# Altium schematic sheet Power_meter.SchDoc
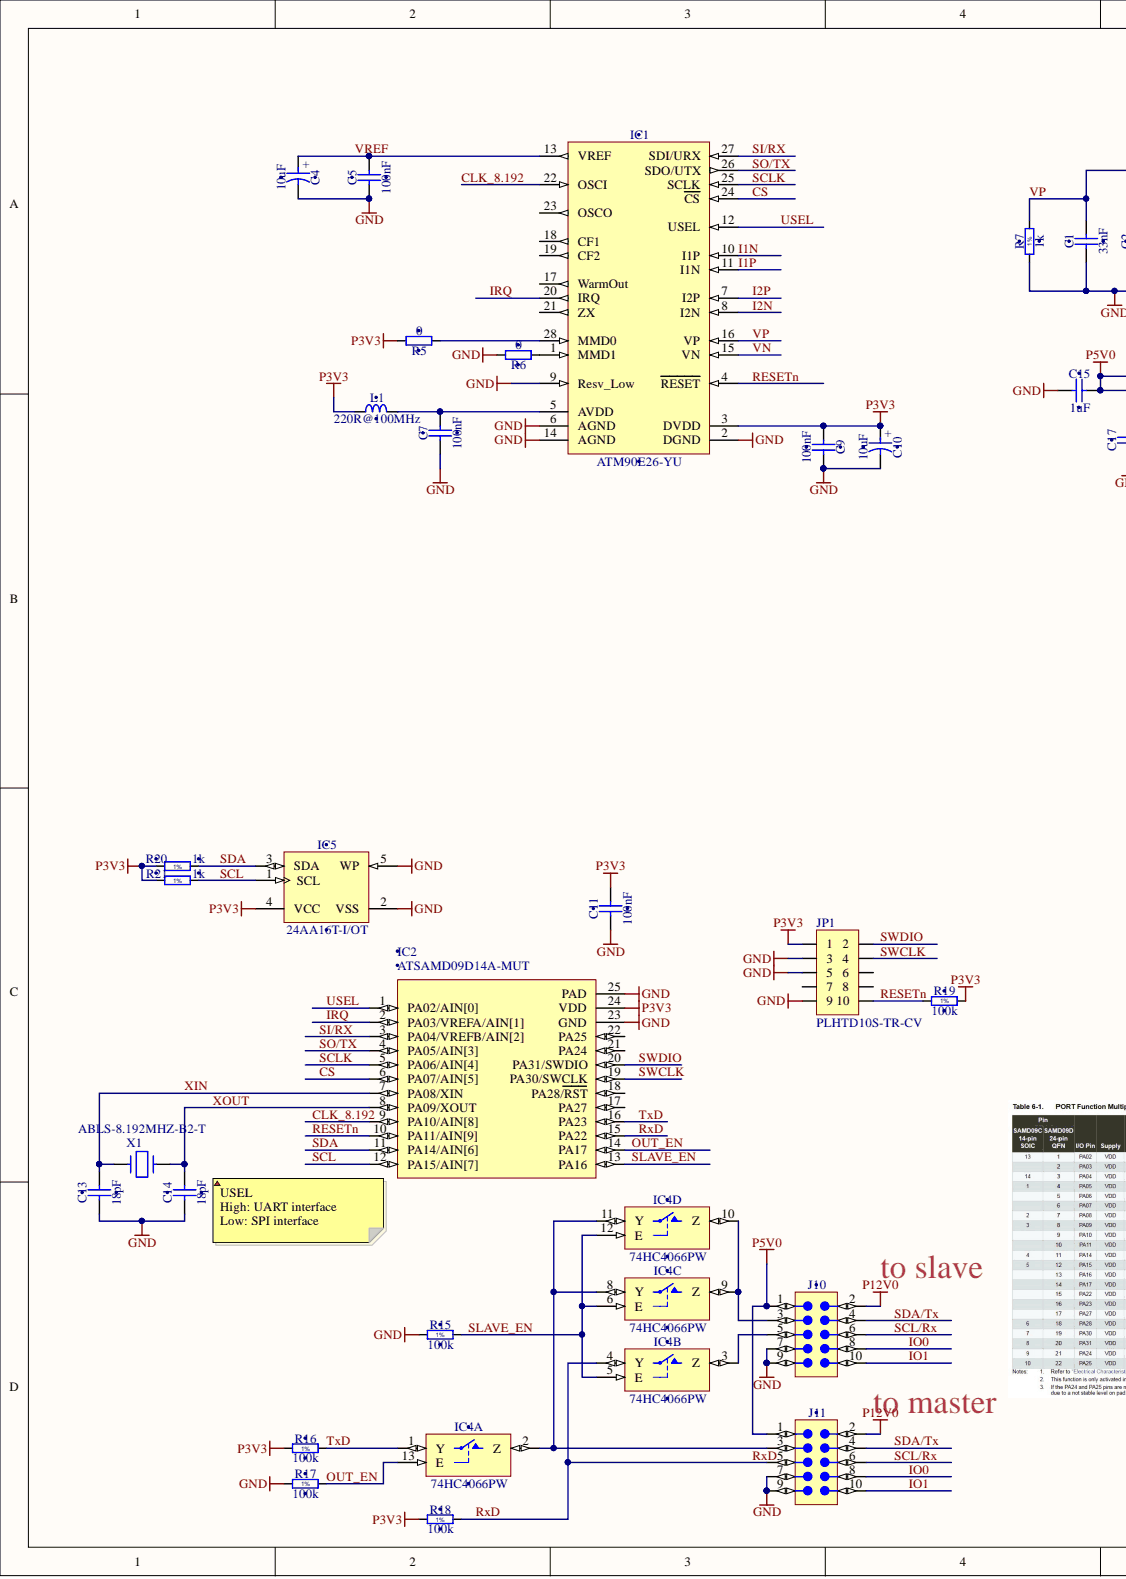
[diagram p0: Power_meter.SchDoc, left]
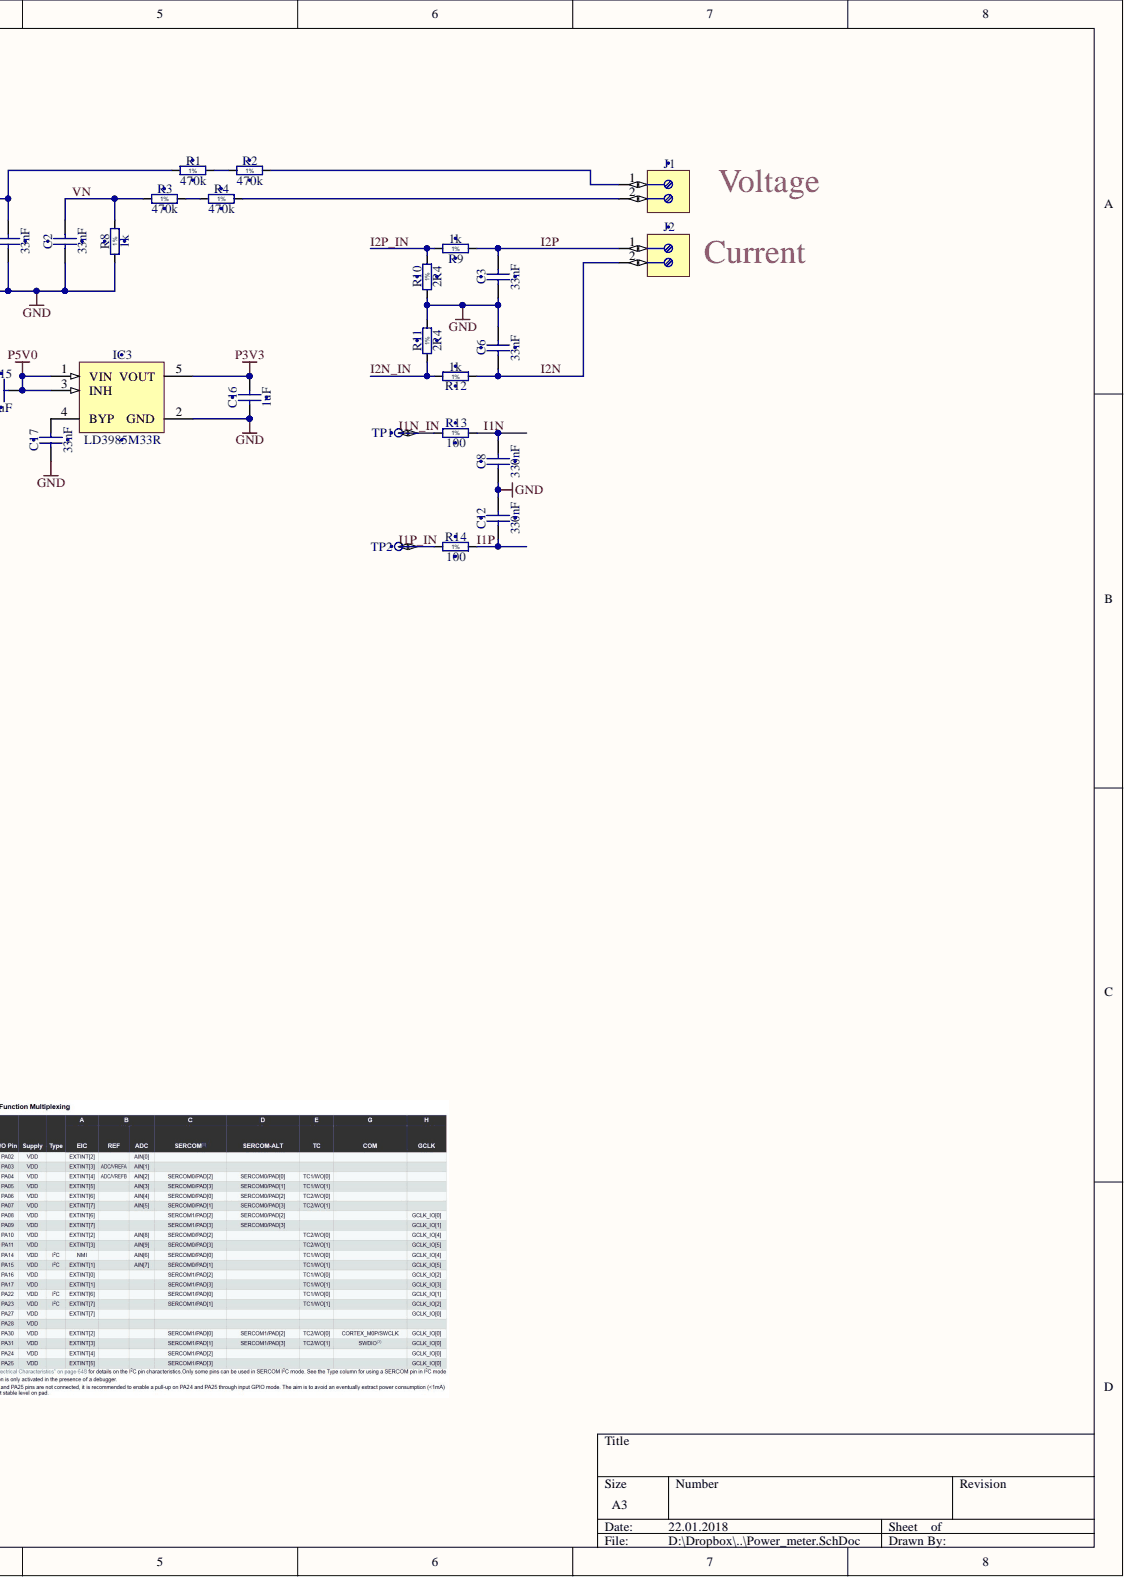
[diagram p1: Power_meter.SchDoc, right]

## Parts
C1  33nF  [CAPC1005X55N]
C2  33nF  [CAPC1005X55N]
C3  33nF  [CAPC1005X55N]
C4  10uF  [CAPMP3216X180N]
C5  100nF  [CAPC1005X55N]
C6  33nF  [CAPC1005X55N]
C7  100nF  [CAPC1005X55N]
C8  330nF  [CAPC1608X87N]
C9  100nF  [CAPC1005X55N]
C10  10uF  [CAPMP3216X180N]
C11  100nF  [CAPC1005X55N]
C12  330nF  [CAPC1608X87N]
C13  18pF  [CAPC1005X55N]
C14  18pF  [CAPC1005X55N]
C15  1uF  [CAPC1005X55N]
C16  1uF  [CAPC1005X55N]
C17  33nF  [CAPC1005X55N]
IC1  ATM90E26-YU  [SOP65P780X200-28N]
IC2  ATSAMD09D14A-MUT  [QFN50P400X400X80_HS-25N]
IC3  LD3985M33R  [SOT95P280X145-5N]
IC4  74HC4066PW  [SOP65P640X110-14N]  (parts A,B,C,D)
IC5  24AA16T-I/OT  [SOT95P280X145-5N]
J1  Family  [PHOENIX_1751248]  (value not drawn)
J2  Family  [PHOENIX_1725656]  (value not drawn)
J10  edge  [AVX_00-9159-010-061-916]  (value not drawn)
J11  edge  [AVX_00-9159-010-061-916]  (value not drawn)
JP1  PLHTD10S-TR-CV  [PLHTD10S-TR-CV]
L1  220R@100MHz  [INDC1005X55N]
R1  470k  [RESC3216X65N]
R2  470k  [RESC3216X65N]
R3  470k  [RESC3216X65N]
R4  470k  [RESC3216X65N]
R5  0  [RESC1005X40N]
R6  0  [RESC1005X40N]
R7  1k  [RESC1005X40N]
R8  1k  [RESC1005X40N]
R9  1k  [RESC1005X40N]
R10  2R4  [RESC1608X55N]
R11  2R4  [RESC1608X55N]
R12  1k  [RESC1005X40N]
R13  100  [RESC1005X40N]
R14  100  [RESC1005X40N]
R15  100k  [RESC1005X40N]
R16  100k  [RESC1005X40N]
R17  100k  [RESC1005X40N]
R18  100k  [RESC1005X40N]
R19  100k  [RESC1005X40N]
R20  1k  [RESC1005X40N]
R21  1k  [RESC1005X40N]
TP1  Test Point  [TPS127]  (value not drawn)  (no BOM)
TP2  Test Point  [TPS127]  (value not drawn)  (no BOM)
X1  ABLS-8.192MHZ-B2-T  [HC-49SM]

## Nets
GND: C1.1 C2.1 C3.1 C4.2 C5.1 C6.2 C7.1 C8.1 C9.2 C10.2 C11.1 C12.2 C13.1 C14.1 C15.1 C16.1 C17.1 IC1.2(DGND) IC1.6(AGND) IC1.9(Resv_Low) IC1.14(AGND) IC2.23(GND) IC2.25(PAD) IC3.2(GND) IC4.7 IC5.2(VSS) IC5.5(WP) J10.7 J10.9 J11.7 J11.9 JP1.3 JP1.5 JP1.9 R6.2 R7.1 R8.1 R10.1 R11.2 R15.1 R17.1
P3V3: C9.1 C10.1 C11.2 C16.2 IC1.3(DVDD) IC2.24(VDD) IC3.5(VOUT) IC4.14 IC5.4(VCC) JP1.1 L1.1 R5.2 R16.1 R18.1 R19.2 R20.2 R21.2
P5V0: C15.2 IC3.1(VIN) IC3.3(INH) J10.1 J11.1
P12V0: J10.2 J11.2
CLK_8.192: IC1.22(OSCI) IC2.9(PA10/AIN[8])
CS: IC1.24(CS) IC2.6(PA07/AIN[5])
I1N: C8.2 IC1.10(I1P) R13.2
I1N_IN: R13.1 TP1.1
I1P: C12.1 IC1.11(I1N) R14.2
I1P_IN: R14.1 TP2.1
I2N: C6.1 IC1.8(I2N) J2.2 R12.1
I2N_IN: R11.1 R12.2
I2P: C3.2 IC1.7(I2P) J2.1 R9.1
I2P_IN: R9.2 R10.2
IO0: J10.8 J11.8
IO1: J10.10 J11.10
IRQ: IC1.20(IRQ) IC2.2(PA03/VREFA/AIN[1])
OUT_EN: IC2.14(PA17) IC4.13(E) R17.2
RESETn: IC1.4(RESET) IC2.10(PA11/AIN[9]) JP1.10 R19.1
RxD: IC2.15(PA22) IC4.4(Y) J11.5 R18.2
SCL: IC2.12(PA15/AIN[7]) IC5.1(SCL) R21.1
SCL/Rx: J10.6 J11.6
SCLK: IC1.25(SCLK) IC2.5(PA06/AIN[4])
SDA: IC2.11(PA14/AIN[6]) IC5.3(SDA) R20.1
SDA/Tx: J10.4 J11.4
SI/RX: IC1.27(SDI/URX) IC2.3(PA04/VREFB/AIN[2])
SLAVE_EN: IC2.13(PA16) IC4.5(E) IC4.6(E) IC4.12(E) R15.2
SO/TX: IC1.26(SDO/UTX) IC2.4(PA05/AIN[3])
SWCLK: IC2.19(PA30/SWCLK) JP1.4
SWDIO: IC2.20(PA31/SWDIO) JP1.2
TxD: IC2.16(PA23) IC4.1(Y) R16.2
USEL: IC1.12(USEL) IC2.1(PA02/AIN[0])
VN: C2.2 IC1.15(VN) R3.1 R8.2
VP: C1.2 IC1.16(VP) R1.1 R7.2
VREF: C4.1 C5.2 IC1.13(VREF)
XIN: C13.2 IC2.7(PA08/XIN) X1.1
XOUT: C14.2 IC2.8(PA09/XOUT) X1.2
NetC7_2: C7.2 IC1.5(AVDD) L1.2
NetC17_2: C17.2 IC3.4(BYP)
NetIC1_1: IC1.1(MMD1) R6.1
NetIC1_28: IC1.28(MMD0) R5.1
NetIC4_2: IC4.2(Z) IC4.8(Y) IC4.11(Y) J11.3
NetIC4_3: IC4.3(Z) J10.5
NetIC4_9: IC4.9(Z) IC4.10(Z) J10.3
NetJ1_1: J1.1 R2.2
NetJ1_2: J1.2 R4.2
NetR1_2: R1.2 R2.1
NetR3_2: R3.2 R4.1

Not connected: IC1 17(WarmOut) 18(CF1) 19(CF2) 21(ZX) 23(OSCO); IC2 17(PA27) 18(PA28/RST) 21(PA24) 22(PA25); JP1 6 7 8

## Library data of the parts
C1-C3 C6 C17 = CC0402_33NF_16V_10%_X7R: Non-polarised capacitor; Manufacturer: GENERIC; Manufacturer1 Part Number: 223878715643; Part Description: SMD Multilayer Chip Ceramic Capacitor; Database Table Name: Capacitors SMD; TC: X7R; Tolerance: ±10%; Voltage: 16V; Case: 0402; Component Kind: Standard; Component Type: Standard; CreateDate: 03.12.2007; HelpURL: \\cern.ch\dfs\Applications\Altium\Datasheets\CC0402_X7R_PHYCOMP.pdf; LatestRevisionDate: 03.12.2007; Library Name: Capacitors.DbLib; Manufacturer1 ComponentHeight: 0.55mm; Manufacturer1 Example: PHYCOMP; Manufacturer Part Number: CC0402_33NF_16V_10%_X7R; Mounted: Yes; Part Number: CC0402_33NF_16V_10%_X7R; Pin Count: 2; Sense: No; SMD: Yes; Socket: No; Status: Not Recommended; Value: 33nF; Author: CERN DEM JLC
C4 C10 = CTE3216-18_10UF_16V_20%_AVX_TCJ: Polarised capacitor; Manufacturer: AVX; Manufacturer Part Number: TCJA106M016R0200; Part Description: ESR 0R200 Tantalum Solid Electrolytic Chip Capacitor with Conductive Polymer Electrode; Database Table Name: Capacitors SMD; Tolerance: ±20%; Voltage: 16V; Case: A; ComponentHeight: 1.8mm; Component Kind: Standard; Component Type: Standard; CreateDate: 29.03.2012; HelpURL: \\cern.ch\dfs\Applications\Altium\Datasheets\CTE_AVX_TCJ.pdf; LatestRevisionDate: 29.03.2012; Library Name: Capacitors.DbLib; Mounted: Yes; Part Number: CTE3216-18_10UF_16V_20%_AVX_TCJ; Pin Count: 2; Sense: No; SMD: Yes; Socket: No; Status: Preferred; Value: 10uF; Author: CERN DEM JLC
C5 C7 C9 C11 = CC0402_100NF_16V_10%_X5R: Non-polarised capacitor; Manufacturer: GENERIC; Manufacturer1 Part Number: GRM155R61C104KA88D; Part Description: SMD Multilayer Chip Ceramic Capacitor; Database Table Name: Capacitors SMD; TC: X5R; Tolerance: ±10%; Voltage: 16V; Case: 0402; Component Kind: Standard; Component Type: Standard; CreateDate: 10.12.2013; HelpURL: \\cern.ch\dfs\Applications\Altium\Datasheets\CC0402_X5R_MURATA_GRM.pdf; LatestRevisionDate: 10.12.2013; Library Name: Capacitors.DbLib; Manufacturer1 ComponentHeight: 0.55mm; Manufacturer1 Example: MURATA; Manufacturer Part Number: CC0402_100NF_16V_10%_X5R; Mounted: Yes; Part Number: CC0402_100NF_16V_10%_X5R; Pin Count: 2; Sense: No; SMD: Yes; Socket: No; Status: None; Value: 100nF; Author: CERN DEM JLC
C8 C12 = CC0603_330NF_16V_10%_X5R: Non-polarised capacitor; Manufacturer: GENERIC; Manufacturer1 Part Number: 223878613656; Part Description: SMD Multilayer Chip Ceramic Capacitor; Database Table Name: Capacitors SMD; TC: X5R; Tolerance: ±10%; Voltage: 16V; Case: 0603; Component Kind: Standard; Component Type: Standard; CreateDate: 03.12.2007; HelpURL: \\cern.ch\dfs\Applications\Altium\Datasheets\CC0805_X5R_PHYCOMP_HC.pdf; LatestRevisionDate: 03.12.2007; Library Name: Capacitors.DbLib; Manufacturer1 ComponentHeight: 0.87mm; Manufacturer1 Example: PHYCOMP; Manufacturer Part Number: CC0603_330NF_16V_10%_X5R; Mounted: Yes; Part Number: CC0603_330NF_16V_10%_X5R; Pin Count: 2; Sense: No; SMD: Yes; Socket: No; Status: Preferred; Value: 330nF; Author: CERN DEM JLC
C13 C14 = CC0402_18PF_50V_5%_C0G: Non-polarised capacitor; Manufacturer: GENERIC; Manufacturer1 Part Number: C0402C180J5GACTU; Part Description: SMD Multilayer Chip Ceramic Capacitor; Database Table Name: Capacitors SMD; TC: C0G/NP0; Tolerance: ±5%; Voltage: 50V; Case: 0402; Component Kind: Standard; Component Type: Standard; CreateDate: 04.10.2016; HelpURL: \\cern.ch\dfs\Applications\Altium\Datasheets\CC0805_C0G_KEMET_(Commercial Grade).pdf; LatestRevisionDate: 04.10.2016; Library Name: Capacitors.DbLib; Manufacturer1 ComponentHeight: 0.55mm; Manufacturer1 Example: KEMET; Manufacturer Part Number: CC0402_18PF_50V_5%_C0G; Mounted: Yes; Part Number: CC0402_18PF_50V_5%_C0G; Pin Count: 2; Sense: No; SMD: Yes; Socket: No; Status: Preferred; Value: 18pF; Author: CERN DEM JLC
C15 C16 = CC0402_1UF_16V_10%_X5R: Non-polarised capacitor; Manufacturer: GENERIC; Manufacturer1 Part Number: C1005X5R1C105KT; Part Description: SMD Multilayer Chip Ceramic Capacitor; Database Table Name: Capacitors SMD; TC: X5R; Tolerance: ±10%; Voltage: 16V; Case: 0402; Component Kind: Standard; Component Type: Standard; CreateDate: 25.10.2012; HelpURL: \\cern.ch\dfs\Applications\Altium\Datasheets\CC0402_X5R_TDK_C.pdf; LatestRevisionDate: 25.10.2012; Library Name: Capacitors.DbLib; Manufacturer1 ComponentHeight: 0.55mm; Manufacturer1 Example: TDK; Manufacturer Part Number: CC0402_1UF_16V_10%_X5R; Mounted: Yes; Part Number: CC0402_1UF_16V_10%_X5R; Pin Count: 2; Sense: No; SMD: Yes; Socket: No; Status: Preferred; Value: 1uF; Author: CERN DEM JLC
IC1 = ATM90E26-YU: Single-Phase High-Performance Wide-Span, Energy Metering IC; Manufacturer: MICROCHIP TECHNOLOGY; Bonding: No; Database Table Name: Analog & Interface; PressFit: No; Case: SSOP28; ComponentHeight: 2mm; Component Kind: Standard; Component Type: Standard; CreateDate: 13.12.2017; Device: ATM90E26-YU; HelpURL: \\cern.ch\dfs\Applications\Altium\Datasheets\ATM90E26-YU.pdf; LatestRevisionDate: 13.12.2017; Library Name: ICs And Semiconductors.DbLib; Manufacturer Part Number: ATM90E26-YU; Mounted: Yes; PackageDescription: SOP, 0.65mm Pitch; 28 pin, 5.30mm W X 10.20mm L X 2.00mm H body, IPC Medium Density; Part Description: Single-Phase High-Performance Wide-Span, Energy Metering IC; Part Number: ATM90E26-YU; Pin Count: 28; Sense: No; SMD: Yes; Socket: No; Author: CERN DEM MR
IC2 = (no symbol name): 32-bit ARM®-based Cortex®-M4 core Microcontroller, 1.62V to 3.6V, 120MHz, 256KB Flash, 64KB of SRAM, 48 QFP, Industrial Grade (-40°C to 85°C); Manufacturer: Microchip; Part Description: ATSAMD09D14A-MUT  SMART SAMD09, SMART ARM based Microcontrollers, 32 bity, 48 MHz, 16 KB, 4 KB; Bonding: No; PressFit: No; Case: QFN24; ComponentHeight: 1.6mm; Component Kind: Standard; Component Type: Standard; CreateDate: 16.12.2017; Device: ATSAMD09D14A-MUT; HelpURL: \\cern.ch\dfs\Applications\Altium\Datasheets\ATSAM4S4AA-AU.pdf; LatestRevisionDate: 16.12.2017; Library Name: LIBRARY_SCH.SCHLIB; Manufacturer Part Number: ATSAMD09D14A-MUT; Mounted: Yes; PackageDescription: QFN, 24-Leads, Body 4.00x4.00mm, Pitch 0.50mm, Thermal Pad 2.60x2.60mm, IPC Medium Density; Part Number: ATSAMD09D14A-MUT; Pin Count: 24; Sense: No; SMD: Yes; Socket: No; Author: GK
IC3 = LD3985M33R: Ultra low drop-low noise BiCMOS voltage regulators low ESR capacitors compatible; Manufacturer: ST MICROELECTRONICS; Part Description: 3.3V, 150mA Ultra low drop-low noise BiCMOS voltage regulators low ESR capacitors compatible; Bonding: No; PressFit: No; Case: SOT23-5; ComponentHeight: 1.45mm; Component Kind: Standard; Component Type: Standard; CreateDate: 23.10.2014; Database Table Name: Regulators; Device: LD3985M33R; HelpURL: \\cern.ch\dfs\Applications\Altium\Datasheets\LD3985M33R.pdf; LatestRevisionDate: 23.10.2014; Library Name: ICs And Semiconductors.DbLib; Manufacturer Part Number: LD3985M33R; Mounted: Yes; PackageDescription: SOT, 0.95mm pitch; 5 pin, 1.60mm W X 2.90mm L X 1.45mm H body, IPC Medium Density; Part Number: LD3985M33R; Pin Count: 5; Sense: No; SMD: Yes; Socket: No; Author: CERN DEM JLC
IC4 = 74HC4066PW: Manufacturer: NXP SEMICONDUCTORS; Part Description: Quad Single-Pole Single-Throw Analog Switch; Bonding: No; Database Table Name: Standard Logic; PressFit: No; Case: TSSOP14; ComponentHeight: 1.1mm; Component Kind: Standard; Component Type: Standard; CreateDate: 19.11.2014; Device: 74HC4066PW; HelpURL: \\cern.ch\dfs\Applications\Altium\Datasheets\74HC4066PW.pdf; LatestRevisionDate: 19.11.2014; Library Name: ICs And Semiconductors.DbLib; Manufacturer Part Number: 74HC4066PW; Mounted: Yes; PackageDescription: SOP, 14-Leads, Body 4.4x5mm (max), Pitch 0.65mm, IPC Medium Density; Part Number: 74HC4066PW; Pin Count: 14; Sense: No; SMD: Yes; Socket: No; Author: CERN DEM JLC
IC5 = (no symbol name): 1.7V-5.5V, 2K I2C Serial EEPROM With EUI-48 Node Identity; Manufacturer: MICROCHIP TECHNOLOGY; Part Description: 1.7V-5.5V, 16K I2C Serial EEPROM; Bonding: No; PressFit: No; Case: SOT23-5; ComponentHeight: 1.45mm; Component Kind: Standard; Component Type: Standard; CreateDate: 31.03.2016; Device: 24AA16T-I/OT; HelpURL: \\cern.ch\dfs\Applications\Altium\Datasheets\24AA02E48T-I-OT.pdf; LatestRevisionDate: 31.03.2016; Library Name: LIBRARY_SCH.SCHLIB; Manufacturer Part Number: 24AA16T-I/OT; Mounted: Yes; PackageDescription: SOT, 0.95mm pitch; 5 pin, 1.60mm W X 2.90mm L X 1.45mm H body, IPC Medium Density; Part Number: 24AA16T-I/OT; Pin Count: 5; Sense: No; SMD: Yes; Socket: No; Author: GK
J1 = PHOENIX_1751248: Terminal Block, 2 Positions, Screw Connection; Manufacturer: PHOENIX CONTACT; Manufacturer Part Number: 1751248 (MKDS 1/ 2-3,5); Part Description: 10A 160V, Terminal Block, Pitch 3.5mm, 2 Positions, Screw Connection; PressFit: No; ComponentHeight: 8.5mm; Component Kind: Standard; Component Type: Standard; CreateDate: 03.11.2009; Database Table Name: PHOENIX; HelpURL: \\cern.ch\dfs\Applications\Altium\Datasheets\PHOENIX_1751248.pdf; LatestRevisionDate: 26.04.2010; Library Name: Connectors.DbLib; Mounted: Yes; Part Number: PHOENIX_1751248; Pin Count: 2; Sense: No; SMD: No; Socket: No; Status: None; Author: CERN DEM JLC
J2 = PHOENIX_1725656: Terminal Block, 2 Positions, Screw Connection; Manufacturer: PHOENIX CONTACT; Manufacturer Part Number: 1725656 (MPT 0,5/2-2,54); Part Description: 6A, 63V, Terminal Block, Pitch 2.54mm, 2 Positions, Screw Connection; PressFit: No; ComponentHeight: 8.5mm; Component Kind: Standard; Component Type: Standard; CreateDate: 07.11.2008; Database Table Name: PHOENIX; HelpURL: \\cern.ch\dfs\Applications\Altium\Datasheets\PHOENIX_1725656.pdf; LatestRevisionDate: 26.04.2010; Library Name: Connectors.DbLib; Mounted: Yes; Part Number: PHOENIX_1725656; Pin Count: 2; Sense: No; SMD: No; Socket: No; Status: None; Author: CERN DEM JMW
J10 J11 = (no symbol name): edge inline connector; Manufacturer: AVX; Part Description: 2.00mm (.079") Pitch Milli-Grid™ Header, Vertical, Shrouded, Center Polarization Slot, Locking Window, 14 Circuits (Customized PCB Edge assembly); PressFit: No; ComponentHeight: 3.15mm; Component Kind: Standard; Component Type: Standard; CreateDate: 13.04.2010; Family: edge; HelpURL: \\cern.ch\dfs\Applications\Altium\Datasheets\MOLEX_87831-1420.pdf; LatestRevisionDate: 13.04.2010; Library Name: LIBRARY_SCH.SCHLIB; Manufacturer Part Number: AVX_00-9159-010-061-916; Mounted: Yes; Part Number: AVX_00-9159-010-061-916; Pin Count: 14; Sense: No; SMD: No; Socket: No; Status: None; Author: GK
JP1 = CON_2x5 1.27 SMT Male: 1.27 mm dual row goldpin (Maritex); Component Kind: Standard; Library Name: LIBRARY_SCH.SCHLIB
L1 = IND0402_MURATA_BLM15HB221SN1: Inductor; Manufacturer: MURATA; Part Description: SMD EMIFIL Suppression Chip Ferrite Bead; Database Table Name: Inductors SMD; Power: 250mA; Resistance: 1R; Tolerance: ±25%; Case: 0402; ComponentHeight: 0.55mm; Component Kind: Standard; Component Type: Standard; CreateDate: 23.06.2009; HelpURL: \\cern.ch\dfs\Applications\Altium\Datasheets\IND0402_MURATA_BLM15H.pdf; LatestRevisionDate: 23.06.2009; Library Name: Inductors & Transformers.DbLib; Manufacturer Part Number: BLM15HB221SN1; Mounted: Yes; Part Number: IND0402_MURATA_BLM15HB221SN1; Pin Count: 2; Sense: No; SMD: Yes; Socket: No; Status: None; Value: 220R@100MHz; Author: CERN DEM JLC
R1-R4 = R1206_470K_1%_0.25W_100PPM: Resistor - 1%; Manufacturer: GENERIC; Manufacturer1 Part Number: NRC12F4703TRF; Part Description: General Purpose Thick Film Chip Resistor; Database Table Name: Resistors SMD; Power: 0.25W; PressFit: No; TC: ±100ppm/°C; Case: 1206; Component Kind: Standard; Component Type: Standard; CreateDate: 03.12.2007; HelpURL: \\cern.ch\dfs\Applications\Altium\Datasheets\R1206_NIC_NRC.pdf; LatestRevisionDate: 13.03.2008; Library Name: Resistors.DbLib; Manufacturer1 ComponentHeight: 0.65mm; Manufacturer1 Example: NIC COMPONENT; Manufacturer Part Number: R1206_470K_1%_0.25W_100PPM; Mounted: Yes; Part Number: R1206_470K_1%_0.25W_100PPM; Pin Count: 2; Sense: No; SMD: Yes; Socket: No; Status: Preferred; Tolerance: ±1%; Value: 470k; Author: CERN DEM JLC
R5 R6 = R0402_0R_JUMPER: Resistor; Manufacturer: GENERIC; Manufacturer1 Part Number: 232270591001L; Part Description: 1A (0.05R Max DC Resistance) Zero Ohm Jumper; Database Table Name: Resistors SMD; PressFit: No; Case: 0402; Component Kind: Standard; Component Type: Standard; CreateDate: 03.12.2007; HelpURL: \\cern.ch\dfs\Applications\Altium\Datasheets\R0402_Phycomp_RC0402.pdf; LatestRevisionDate: 17.10.2012; Library Name: Resistors.DbLib; Manufacturer1 ComponentHeight: 0.4mm; Manufacturer1 Example: YAGEO PHYCOMP; Manufacturer Part Number: R0402_0R_JUMPER; Mounted: Yes; Part Number: R0402_0R_JUMPER; Pin Count: 2; Sense: No; SMD: Yes; Socket: No; Status: Not Recommended; Value: 0; Author: CERN DEM JLC
R7-R9 R12 R20 R21 = R0402_1K_1%_0.0625W_100PPM: Resistor - 1%; Manufacturer: GENERIC; Manufacturer1 Part Number: 232270671002L; Part Description: General Purpose Thick Film Chip Resistor; Database Table Name: Resistors SMD; PressFit: No; TC: ±100ppm/°C; Case: 0402; Component Kind: Standard; Component Type: Standard; CreateDate: 03.12.2007; HelpURL: \\cern.ch\dfs\Applications\Altium\Datasheets\R0402_Phycomp_RC0402.pdf; LatestRevisionDate: 17.10.2012; Library Name: Resistors.DbLib; Manufacturer1 ComponentHeight: 0.4mm; Manufacturer1 Example: YAGEO PHYCOMP; Manufacturer Part Number: R0402_1K_1%_0.0625W_100PPM; Mounted: Yes; Part Number: R0402_1K_1%_0.0625W_100PPM; Pin Count: 2; Power: 0.0625W; Sense: No; SMD: Yes; Socket: No; Status: Not Recommended; Tolerance: ±1%; Value: 1k; Author: CERN DEM JLC
R10 R11 = R0603_2R4_1%_0.1W_200PPM: Resistor - 1%; Manufacturer: GENERIC; Manufacturer1 Part Number: 232270462408L or RC0603FR-072R4L; Part Description: General Purpose Thick Film Chip Resistor; Database Table Name: Resistors SMD; Power: 0.1W; PressFit: No; TC: ±200ppm/°C; Case: 0603; Component Kind: Standard; Component Type: Standard; CreateDate: 03.12.2007; HelpURL: \\cern.ch\dfs\Applications\Altium\Datasheets\R0603_Tyco_RN73.pdf; LatestRevisionDate: 17.10.2012; Library Name: Resistors.DbLib; Manufacturer1 ComponentHeight: 0.65mm; Manufacturer1 Example: YAGEO PHYCOMP; Manufacturer Part Number: R0603_2R4_1%_0.1W_200PPM; Mounted: Yes; Part Number: R0603_2R4_1%_0.1W_200PPM; Pin Count: 2; Sense: No; SMD: Yes; Socket: No; Status: Preferred; Tolerance: ±1%; Value: 2R4; Author: CERN DEM JLC
R13 R14 = R0402_100R_1%_0.0625W_100PPM: Resistor - 1%; Manufacturer: GENERIC; Manufacturer1 Part Number: 232270671001L; Part Description: General Purpose Thick Film Chip Resistor; Database Table Name: Resistors SMD; PressFit: No; TC: ±100ppm/°C; Case: 0402; Component Kind: Standard; Component Type: Standard; CreateDate: 03.12.2007; HelpURL: \\cern.ch\dfs\Applications\Altium\Datasheets\R0402_Phycomp_RC0402.pdf; LatestRevisionDate: 17.10.2012; Library Name: Resistors.DbLib; Manufacturer1 ComponentHeight: 0.4mm; Manufacturer1 Example: YAGEO PHYCOMP; Manufacturer Part Number: R0402_100R_1%_0.0625W_100PPM; Mounted: Yes; Part Number: R0402_100R_1%_0.0625W_100PPM; Pin Count: 2; Power: 0.0625W; Sense: No; SMD: Yes; Socket: No; Status: Not Recommended; Tolerance: ±1%; Value: 100; Author: CERN DEM JLC
R15-R19 = R0402_100K_1%_0.0625W_100PPM: Resistor - 1%; Manufacturer: GENERIC; Manufacturer1 Part Number: 232270671004L; Part Description: General Purpose Thick Film Chip Resistor; Database Table Name: Resistors SMD; PressFit: No; TC: ±100ppm/°C; Case: 0402; Component Kind: Standard; Component Type: Standard; CreateDate: 03.12.2007; HelpURL: \\cern.ch\dfs\Applications\Altium\Datasheets\R0402_Phycomp_RC0402.pdf; LatestRevisionDate: 17.10.2012; Library Name: Resistors.DbLib; Manufacturer1 ComponentHeight: 0.4mm; Manufacturer1 Example: YAGEO PHYCOMP; Manufacturer Part Number: R0402_100K_1%_0.0625W_100PPM; Mounted: Yes; Part Number: R0402_100K_1%_0.0625W_100PPM; Pin Count: 2; Power: 0.0625W; Sense: No; SMD: Yes; Socket: No; Status: Not Recommended; Tolerance: ±1%; Value: 100k; Author: CERN DEM JLC
TP1 TP2 = SMD_PAD_1.27X1.27MM: Part Description: 1.27mm x 1.27mm PCB Copper Area (SMD Test Point); Database Table Name: Pads; Mounted: No; ComponentHeight: 0mm; Component Type: Standard (No BOM); CreateDate: 12.01.2008; Description: Test Point; Family: Test Point; HelpURL: Undefined; LatestRevisionDate: 12.01.2008; Library Name: Eletro-mechanical.DbLib; PackageDescription: 1.27mm x 1.27mm PCB Copper Area (SMD Test Point); Part Number: SMD_PAD_1.27X1.27MM; Pin Count: 1; Sense: No; SMD: Yes; Socket: No; Status: None; Author: CERN DEM JLC
X1 = X_CRYSTAL_HC49_SMT: Crystal oscillator; Component Kind: Standard; Library Name: LIBRARY_SCH.SCHLIB; Supplier 1: Newark; Supplier Part Number 1: 56P2843
